# Altium schematic sheet FEATHER.SchDoc
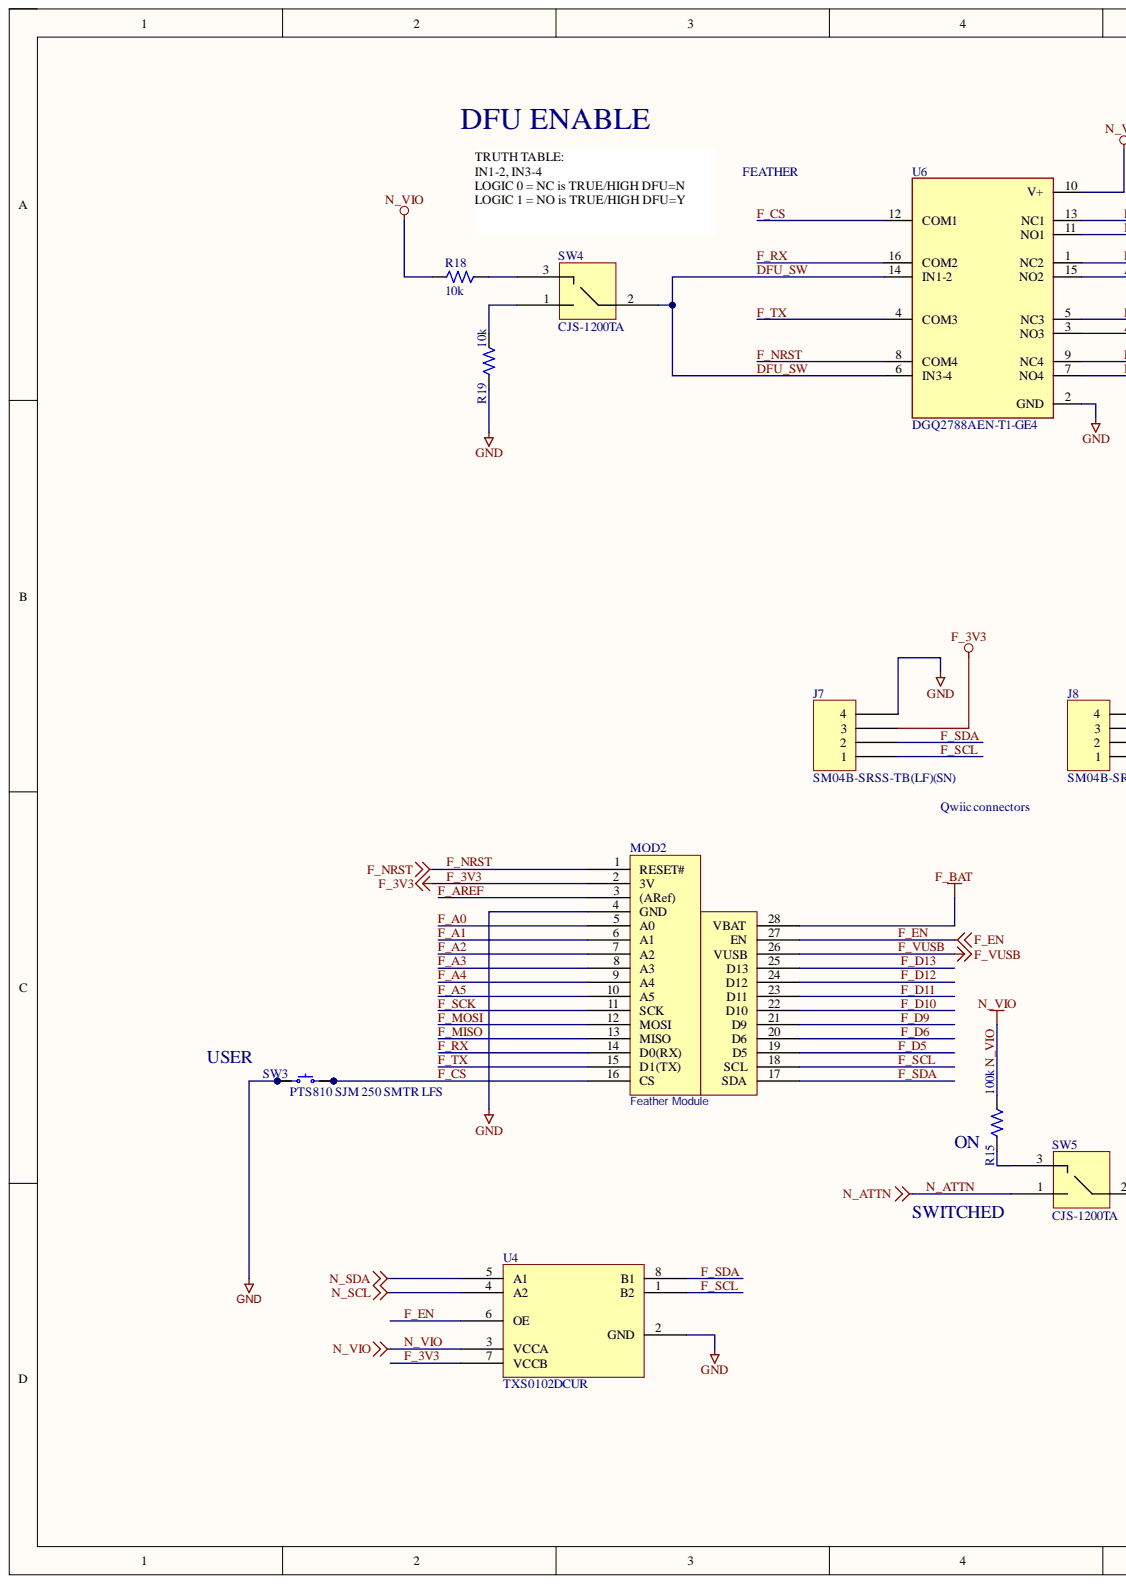
[diagram p0: FEATHER.SchDoc, left]
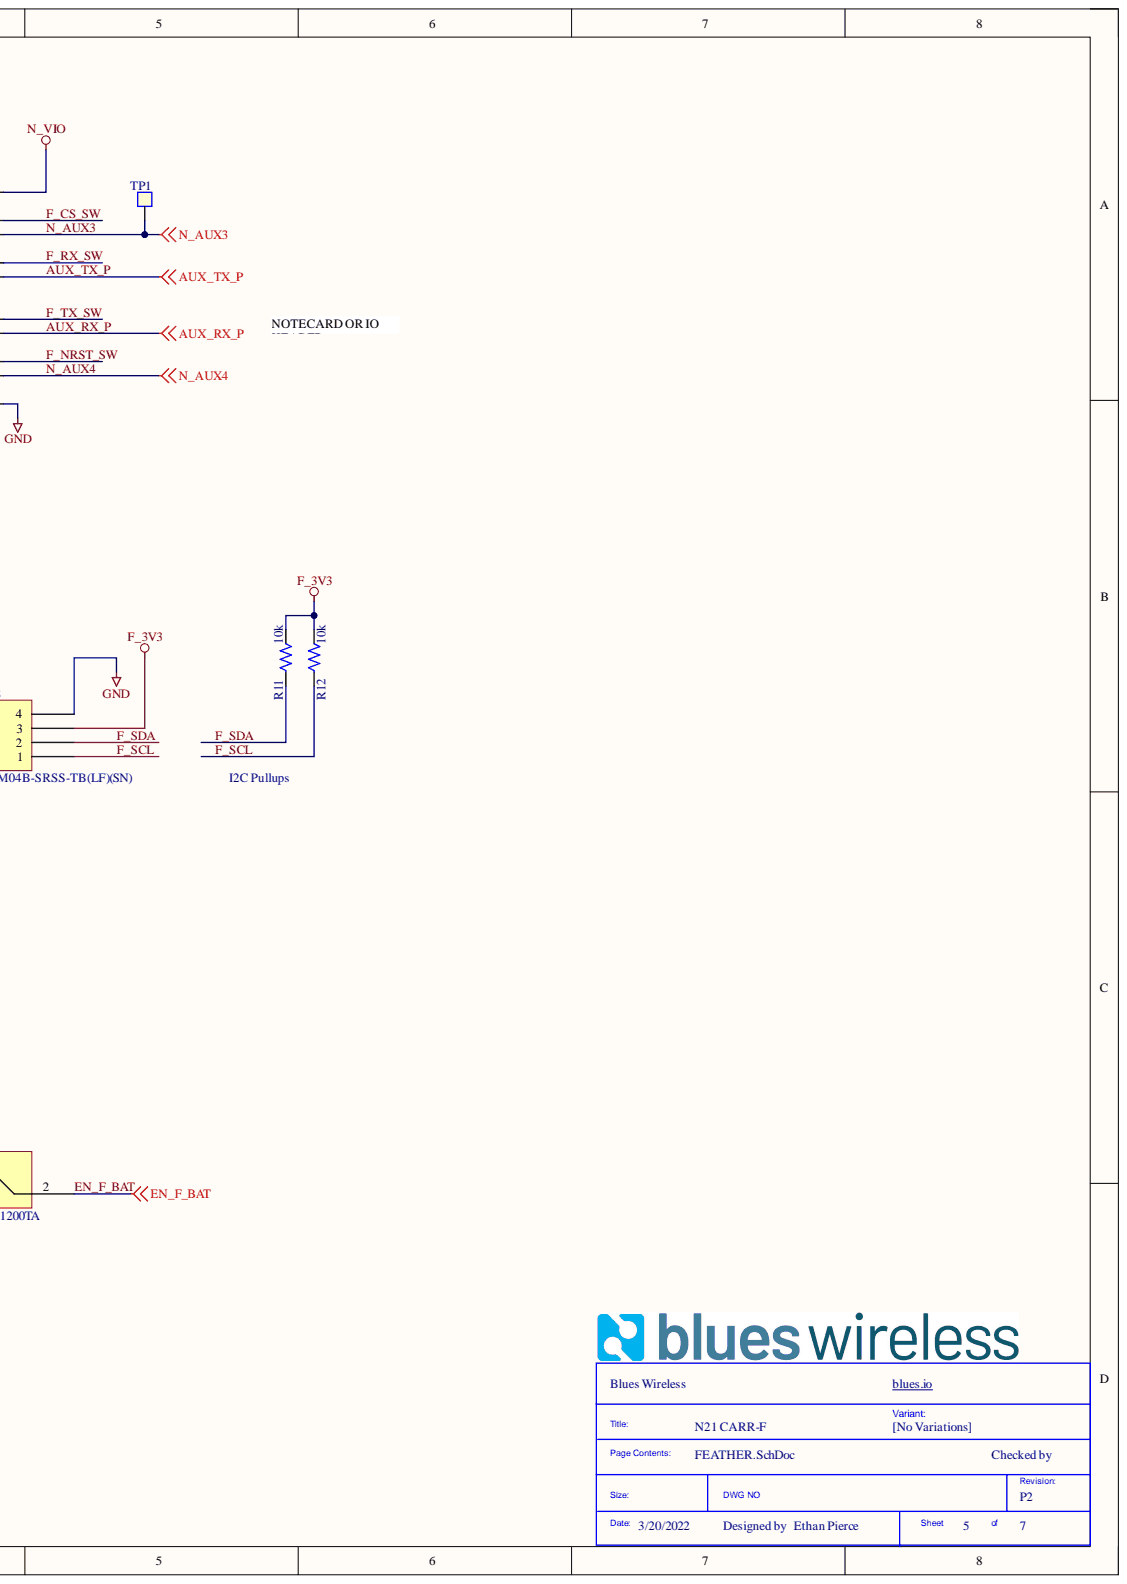
[diagram p1: FEATHER.SchDoc, right]

## Parts
J7  SM04B-SRSS-TB(LF)(SN)  [JST SM04B-SRSS-TB]
J8  SM04B-SRSS-TB(LF)(SN)  [JST SM04B-SRSS-TB]
MOD2  Feather Module  [BLUES_WIRELESS_SWAN_2-54_HEADERS]
R11  10k  [RES 0402_1005]
R12  10k  [RES 0402_1005]
R15  100k  [RES 0402_1005]
R18  10k  [RES 0402_1005]
R19  10k  [RES 0402_1005]
SW3  PTS810 SJM 250 SMTR LFS  Series: PTS810  [C&K PTS810]
SW4  CJS-1200TA  [SW_CJS-1200TA]
SW5  CJS-1200TA  [SW_CJS-1200TA]
TP1  TESTPAD  [TESTPAD_127]  (value not drawn)  (no BOM)
U4  TXS0102DCUR  [SOP50P310X90-8N]
U6  DGQ2788AEN-T1-GE4  [QFN40P260X180X60-16N]

## Nets
F_BAT: MOD2.28(VBAT)
GND: J7.4 J8.4 MOD2.4(GND) R19.1 SW3.1 SW3.2 U4.2(GND) U6.2(GND)
N_SCL: U4.4(A2)
N_SDA: U4.5(A1)
AUX_RX_P: U6.3(NO3)
AUX_TX_P: U6.15(NO2)
DFU_SW: SW4.2 U6.6(IN3-4) U6.14(IN1-2)
EN_F_BAT: SW5.2
F_3V3: J7.3 J8.3 MOD2.2(3V) R11.2 R12.2 U4.7(VCCB)
F_A0: MOD2.5(A0)
F_A1: MOD2.6(A1)
F_A2: MOD2.7(A2)
F_A3: MOD2.8(A3)
F_A4: MOD2.9(A4)
F_A5: MOD2.10(A5)
F_AREF: MOD2.3((ARef))
F_CS: MOD2.16(CS) SW3.3 SW3.4 U6.12(COM1)
F_CS_SW: U6.13(NC1)
F_D5: MOD2.19(D5)
F_D6: MOD2.20(D6)
F_D9: MOD2.21(D9)
F_D10: MOD2.22(D10)
F_D11: MOD2.23(D11)
F_D12: MOD2.24(D12)
F_D13: MOD2.25(D13)
F_EN: MOD2.27(EN) U4.6(OE)
F_MISO: MOD2.13(MISO)
F_MOSI: MOD2.12(MOSI)
F_NRST: MOD2.1(RESET#) U6.8(COM4)
F_NRST_SW: U6.9(NC4)
F_RX: MOD2.14(D0(RX)) U6.16(COM2)
F_RX_SW: U6.1(NC2)
F_SCK: MOD2.11(SCK)
F_SCL: J7.1 J8.1 MOD2.18(SCL) R12.1 U4.1(B2)
F_SDA: J7.2 J8.2 MOD2.17(SDA) R11.1 U4.8(B1)
F_TX: MOD2.15(D1(TX)) U6.4(COM3)
F_TX_SW: U6.5(NC3)
F_VUSB: MOD2.26(VUSB)
N_ATTN: SW5.1
N_AUX3: TP1.1 U6.11(NO1)
N_AUX4: U6.7(NO4)
N_VIO: R15.2 R18.2 U4.3(VCCA) U6.10(V+)
NetR15_1: R15.1 SW5.3
NetR18_1: R18.1 SW4.3
NetR19_2: R19.2 SW4.1

## Library data of the parts
J7 J8 = SM04B-SRSS-TB(LF)(SN): CONN HEADER SH 4POS SIDE 1MM TIN; Manufacturer: JST; Connector Type: Male Pin; Contact Resistance: 40mR; Max Current Rating: 1A; Max Voltage Rating (AC): 50V; Number of Contacts: 4; Pitch: 0.039inch; Category: Connectors; Color: Natural; Component Kind: Standard; ComponentLink1Description: https://datasheet.ciiva.com/26894/getdatasheetpartid-640736-26894676.pdf?src-supplier=Verical; ComponentLink1URL: https://datasheet.ciiva.com/26894/getdatasheetpartid-640736-26894676.pdf?src-supplier=Verical; ComponentLink2Description: https://datasheet.ciiva.com/26894/getdatasheetpartid-499363-26894945.pdf?src-supplier=Verical; ComponentLink2URL: https://datasheet.ciiva.com/26894/getdatasheetpartid-499363-26894945.pdf?src-supplier=Verical; ComponentLink3Description: https://datasheet.ciiva.com/16487/jstsm04b-srss-tb-lf-sn-16487222.pdf?src-supplier=Future+Electronics; ComponentLink3URL: https://datasheet.ciiva.com/16487/jstsm04b-srss-tb-lf-sn-16487222.pdf?src-supplier=Future+Electronics; Contact Material: Copper Alloy; Contact Plating: Tin,Copper; Depth: 4.95mm; Housing Color: Beige; Housing Material: Polyamide; Lead Free: Lead Free; Length: 6mm; Manufacturer Part Number: SM04B-SRSS-TB(LF)(SN); Max Operating Temperature: 85°C; Max Voltage Rating (DC): 50V; Min Operating Temperature: -25°C; Mount: Surface Mount; Number of Positions: 4; Number of Rows: 1; Orientation: Right Angle; Packaging: Tape and Reel; Pricing 1: 1=0.57000, 10=0.49300, 100=0.40630, 500=0.35328, 1000=0.29146 (USD); RoHS: Yes; Supplier 1: Digi-Key; Supplier Part Number 1: 455-1804-1-ND; Termination: Solder; Library Name: notecarrier-afv2-V1I1.SchLib
MOD2 = ADAFRUIT_FEATHER_COMMON_1: Typical Adafruit Feather Pinout; Component Kind: Standard; Library Name: n21-p2.SchLib
R11 R12 R18 R19 = RC1005F103CS: Res Thick Film 0402 10K Ohm 1% 1/16W ±100ppm/°C Molded SMD SMD T/R; Manufacturer: Samsung; Max Power Dissipation: 63mW; Resistance: 10kR; Case Code (Imperial): 0402; Case Code (Metric): 1005; Case/Package: 0402; Category: Chip SMD Resistors; Component Kind: Standard; ComponentLink1Description: https://datasheet.ciiva.com/15196/getdatasheetpartid-504789-15196214.pdf?src-supplier=Verical; ComponentLink1URL: https://datasheet.ciiva.com/15196/getdatasheetpartid-504789-15196214.pdf?src-supplier=Verical; ComponentLink2Description: https://datasheet.ciiva.com/16481/rcseries-16481007.pdf?src-supplier=Future+Electronics; ComponentLink2URL: https://datasheet.ciiva.com/16481/rcseries-16481007.pdf?src-supplier=Future+Electronics; Construction: Rectangular; Contact Plating: Tin; Depth: 0.5mm; Features: Moisture Resistant; Height: 0.016inch; Length: 0.039inch; Manufacturer Part Number: RC1005F103CS; Max Operating Temperature: 155°C; Military Standard: Not; Min Operating Temperature: -55°C; Mount: Surface Mount; Number of Terminations: 2; Package Quantity: 1; Packaging: Tape and Reel; Power Rating: 63mW; Pricing 1: 10000=0.00695 (USD); Radiation Hardening: No; Resistor Type: Thick Film; RoHS: Yes; RoHS Compliant: Yes; Series: RC; Size Code: 0402; Stock 1: 58620569; Supplier 1: Digi-Key; Supplier Part Number 1: 1276-3431-2-ND; Temperature Coefficient: 100ppm/°C; Tolerance: 1%; Width: 0.02inch; Library Name: notecarrier-afv2-V1I1.SchLib
R15 = PFR05S-104-FNH: Res SMD 100 Kohm 1% 1/16W 0402; Manufacturer: Cyntec; Category: Chip SMD Resistors; Component Kind: Standard; Manufacturer Part Number: PFR05S-104-FNH; Pricing 1: 1=0.10000, 10=0.01500, 100=0.00590, 1000=0.00265, 2500=0.00230, 5000=0.00190 (USD); RoHS: Yes; RoHS Compliant: Yes; Stock 1: 2416; Supplier 1: Digi-Key; Supplier Part Number 1: 2037-PFR05S-104-FNHCT-ND; Library Name: notecarrier-afv2-V1I1.SchLib
SW3 = PTS810 SJM 250 SMTR LFS: SWITCH TACTILE SPST-NO 0.05A 16V; Manufacturer: C&K; Actuator Height off PCB, Vertical: 2.50mm; Actuator Orientation: Top Actuated; Actuator Type: Oval Button; Contact Rating @ Voltage: 0.05A @ 16VDC; Database Table Name: Switches - Tactile; Illumination: Non-Illuminated; Operating Force: 160gf; Outline: 4.20mm x 3.20mm; Switch Function: Off-Mom; Circuit: SPST-NO; Component Kind: Standard; ComponentLink1Description: Datasheet; ComponentLink1URL: https://dznh3ojzb2azq.cloudfront.net/products/Tactile/PTS810/documents/datasheet.pdf; ComponentLink2Description: DigiKey Link; ComponentLink2URL: http://digikey.com/product-detail/en/c-k/PTS810-SJM-250-SMTR-LFS/CKN10502CT-ND/4176673; LastUpdated: 4/9/2020 10:00:13 AM; Library Name: Celestial Altium Library - altium_library.DbLib; Lifecycle Status: Active; Minimum Order: 1; Mounting Type: Surface Mount; Operating Temperature: -40°C ~ 85°C; Packaging: TapeAndReel; Part Number: PTS810 SJM 250 SMTR LFS; Part Status: Active; Price: 0.16; Supplier 1: DigiKey; Supplier Part Number 1: CKN10502CT-ND; Termination Style: J Lead
SW4 SW5 = CJS-1200TA: Switch Slide N.O./N.C. SPDT Raised Slide 0.1A 24VDC J-Hook SMD Embossed T/R; Manufacturer: Nidec Copal; Actuator Type: Slide (Standard); Body Material: Polyphenylene; Current Rating: 100mA; Electrical Life: 200Cycles; Max Voltage Rating (DC): 24V; Number of Positions: 2; Voltage Rating (DC): 6V; Washable: Yes; Category: Slide Switches; Component Kind: Standard; ComponentLink1Description: https://datasheet.ciiva.com/2864/cjs-2864476.pdf?src-supplier=Digi-Key; ComponentLink1URL: https://datasheet.ciiva.com/2864/cjs-2864476.pdf?src-supplier=Digi-Key; ComponentLink2Description: https://datasheet.ciiva.com/2864/cjs-2864477.pdf?src-supplier=Digi-Key; ComponentLink2URL: https://datasheet.ciiva.com/2864/cjs-2864477.pdf?src-supplier=Digi-Key; ComponentLink3Description: https://datasheet.ciiva.com/2864/cjs-2864478.pdf?src-supplier=Digi-Key; ComponentLink3URL: https://datasheet.ciiva.com/2864/cjs-2864478.pdf?src-supplier=Digi-Key; Contact Material: Copper Alloy; Contact Plating: Gold; Lead Free: Lead Free; Length: 0.212inch; Manufacturer Part Number: CJS-1200TA; Max Operating Temperature: 85°C; Min Operating Temperature: -40°C; Mount: Surface Mount; Orientation: Vertical; Packaging: Tape and Reel; Pricing 1: 1=0.81000, 10=0.78400, 25=0.75440, 100=0.65470, 250=0.59736, 500=0.55510 (USD); Radiation Hardening: No; RoHS: Yes; RoHS Compliant: Yes; Series: CJS; Supplier 1: Digi-Key; Supplier Part Number 1: 563-1021-6-ND; Termination: J-Hook; Throw Configuration: SPDT; Library Name: notecarrier-afv2-V1I1.SchLib
TP1 = TESTPAD: TESTPOINT; PCB Footprint: FIDUCIAL; Pkg Type: SMD; Distributor: FAE; Library Name: n21-p2.SchLib
U4 = TXS0102DCUR: 2-Bit Bidirectional Voltage-Level Shifter for Open-Drain and Push-Pull Application 8-VSSOP -40 to 85; Manufacturer: Texas Instruments; Data Rate: 24Mbps; High Level Output Current: -20uA; Logic Function: Translator; Low Level Output Current: 1mA; Max Supply Voltage: 5.5V; Min Supply Voltage: 1.65V; Nominal Supply Current: 14.4uA; Number of Bits: 2; Output Current: 1A; Propagation Delay: 4.6ns; Turn-On Delay Time: 260ns; Case/Package: VSSOP; Category: Voltage Level Shifters; Component Kind: Standard; ComponentLink1Description: https://datasheet.ciiva.com/22653/1846850-22653373.pdf?src-supplier=Farnell; ComponentLink1URL: https://datasheet.ciiva.com/22653/1846850-22653373.pdf?src-supplier=Farnell; ComponentLink2Description: https://datasheet.ciiva.com/15096/getdatasheetpartid-118249-15096172.pdf?src-supplier=Verical; ComponentLink2URL: https://datasheet.ciiva.com/15096/getdatasheetpartid-118249-15096172.pdf?src-supplier=Verical; ComponentLink3Description: https://datasheet.ciiva.com/1508/1857232-1508821.pdf?src-supplier=Element14; ComponentLink3URL: https://datasheet.ciiva.com/1508/1857232-1508821.pdf?src-supplier=Element14; ComponentLink4Description: https://datasheet.ciiva.com/28499/1863639-28499676.pdf?src-supplier=Element14; ComponentLink4URL: https://datasheet.ciiva.com/28499/1863639-28499676.pdf?src-supplier=Element14; ComponentLink5Description: https://datasheet.ciiva.com/46395/1863639-46395871.pdf?src-supplier=Element14; ComponentLink5URL: https://datasheet.ciiva.com/46395/1863639-46395871.pdf?src-supplier=Element14; ComponentLink6Description: https://datasheet.ciiva.com/1899/txs0102-1899369.pdf?src-supplier=Digi-Key; ComponentLink6URL: https://datasheet.ciiva.com/1899/txs0102-1899369.pdf?src-supplier=Digi-Key; ComponentLink7Description: https://datasheet.ciiva.com/1899/txs0102-1899368.pdf?src-supplier=Digi-Key; ComponentLink7URL: https://datasheet.ciiva.com/1899/txs0102-1899368.pdf?src-supplier=Digi-Key; ComponentLink8Description: https://datasheet.ciiva.com/1899/txs0102-1899370.pdf?src-supplier=Digi-Key; ComponentLink8URL: https://datasheet.ciiva.com/1899/txs0102-1899370.pdf?src-supplier=Digi-Key; ComponentLink9Description: https://datasheet.ciiva.com/14287/txs0102-14287516.pdf?src-supplier=Avnet; ComponentLink9URL: https://datasheet.ciiva.com/14287/txs0102-14287516.pdf?src-supplier=Avnet; ComponentLink10Description: https://datasheet.ciiva.com/14287/txs0102-14287518.pdf?src-supplier=Avnet; ComponentLink10URL: https://datasheet.ciiva.com/14287/txs0102-14287518.pdf?src-supplier=Avnet; Lead Free: Lead Free; Manufacturer Part Number: TXS0102DCUR; Max Operating Temperature: 85°C; Min Operating Temperature: -40°C; Number of Channels: 2; Number of Inputs: 2; Number of Pins: 8; Output Type: Voltage; Package Quantity: 1; Packaging: Tape and Reel; Pricing 1: 1=0.80000, 10=0.70000, 25=0.65720, 100=0.53640, 250=0.49820, 500=0.42400, 1000=0.35000 (USD); Quiescent Current: 14.4uA; Radiation Hardening: No; REACH SVHC: No SVHC; RoHS: Yes; RoHS Compliant: Yes; Supplier 1: Digi-Key; Supplier Part Number 1: 296-21931-6-ND; Weight: 0.000339oz; Library Name: notecarrier-afv2-V1I1.SchLib
U6 = DGQ2788AEN-T1-GE4: Analog Switch Quad SPDT Automotive 16-Pin Mini-QFN T/R; Manufacturer: Vishay; -3db Bandwidth: 338MHz; Number of Circuits: 2; On-State Resistance: 500mR; Category: Interface ICs; Component Kind: Standard; ComponentLink1Description: http://www.vishay.com/docs/75683/dgq2788a.pdf?src-supplier=Digi-Key; ComponentLink1URL: http://www.vishay.com/docs/75683/dgq2788a.pdf?src-supplier=Digi-Key; Library Name: n21-p2.SchLib; Manufacturer Part Number: DGQ2788AEN-T1-GE4; Max Operating Temperature: 125°C; Min Operating Temperature: -40°C; Number of Pins: 16; Packaging: Tape and Reel; Pricing 1: 1=1.03770, 10=0.89200, 25=0.88310, 100=0.69780, 250=0.68760 (USD); RoHS: Yes; RoHS Compliant: Yes; Stock 1: 341; Supplier 1: Arrow Electronics; Supplier Part Number 1: DGQ2788AEN-T1-GE4
